# Altium schematic sheet 15_PMU.SchDoc
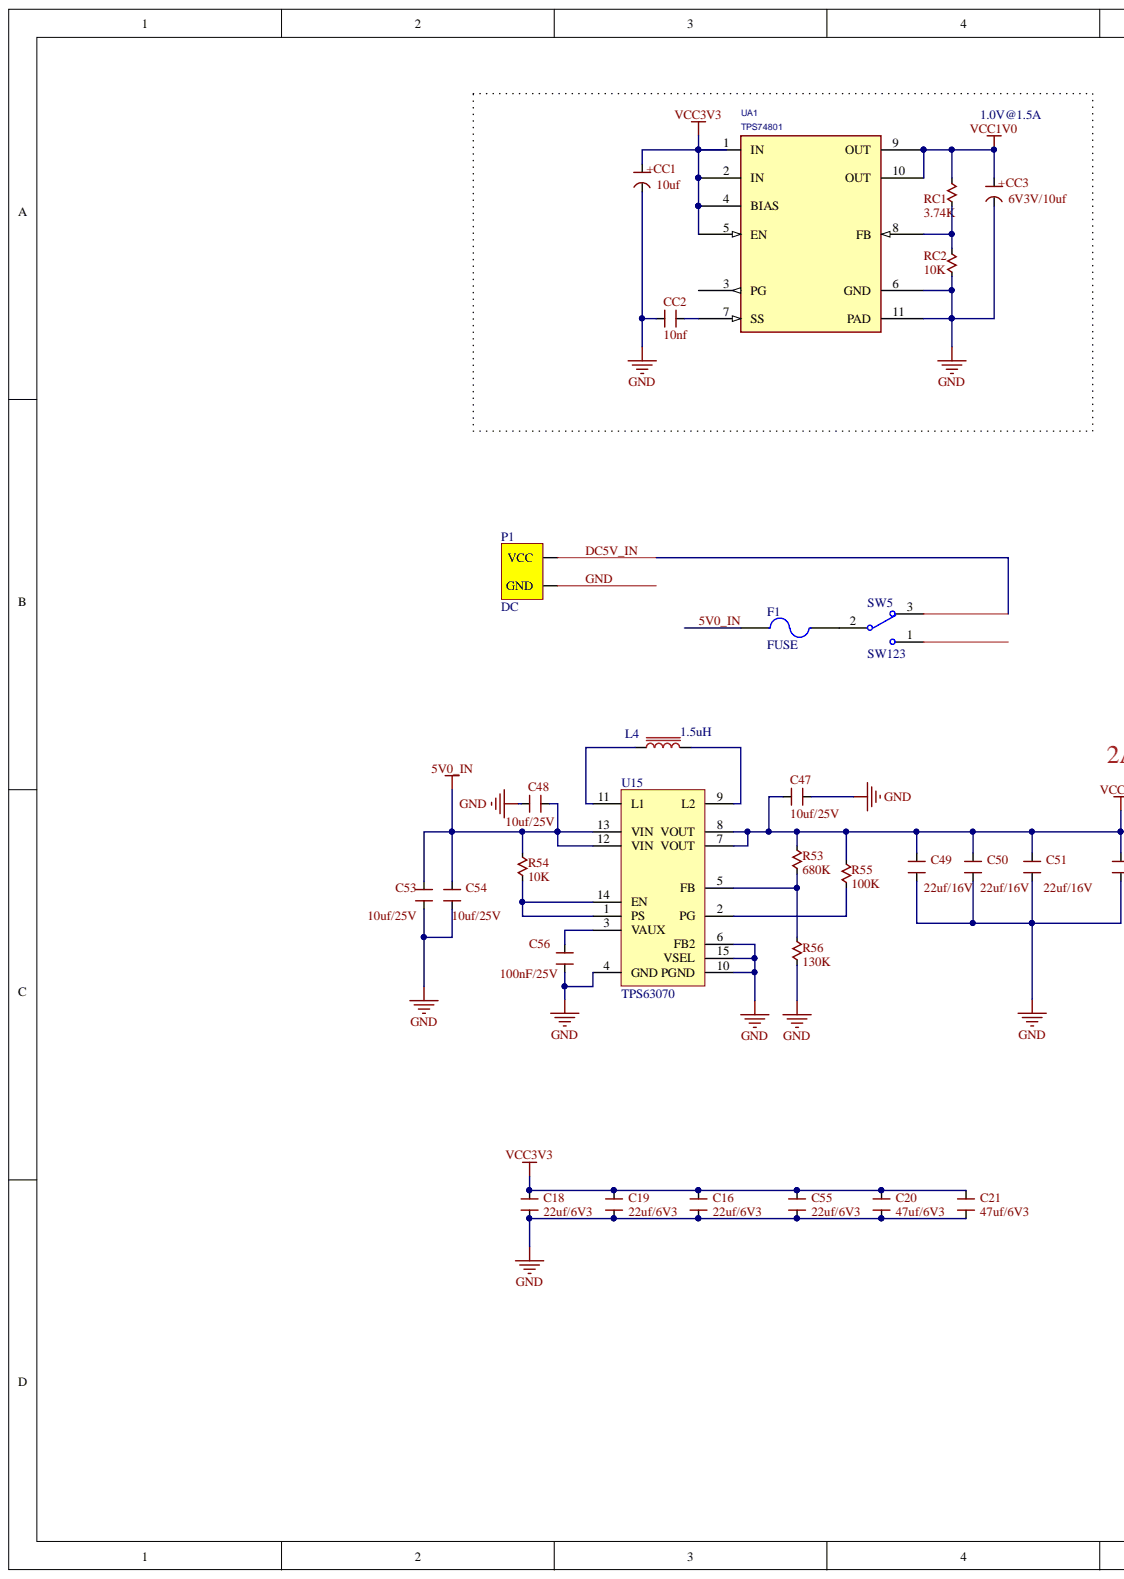
[diagram p0: 15_PMU.SchDoc, left]
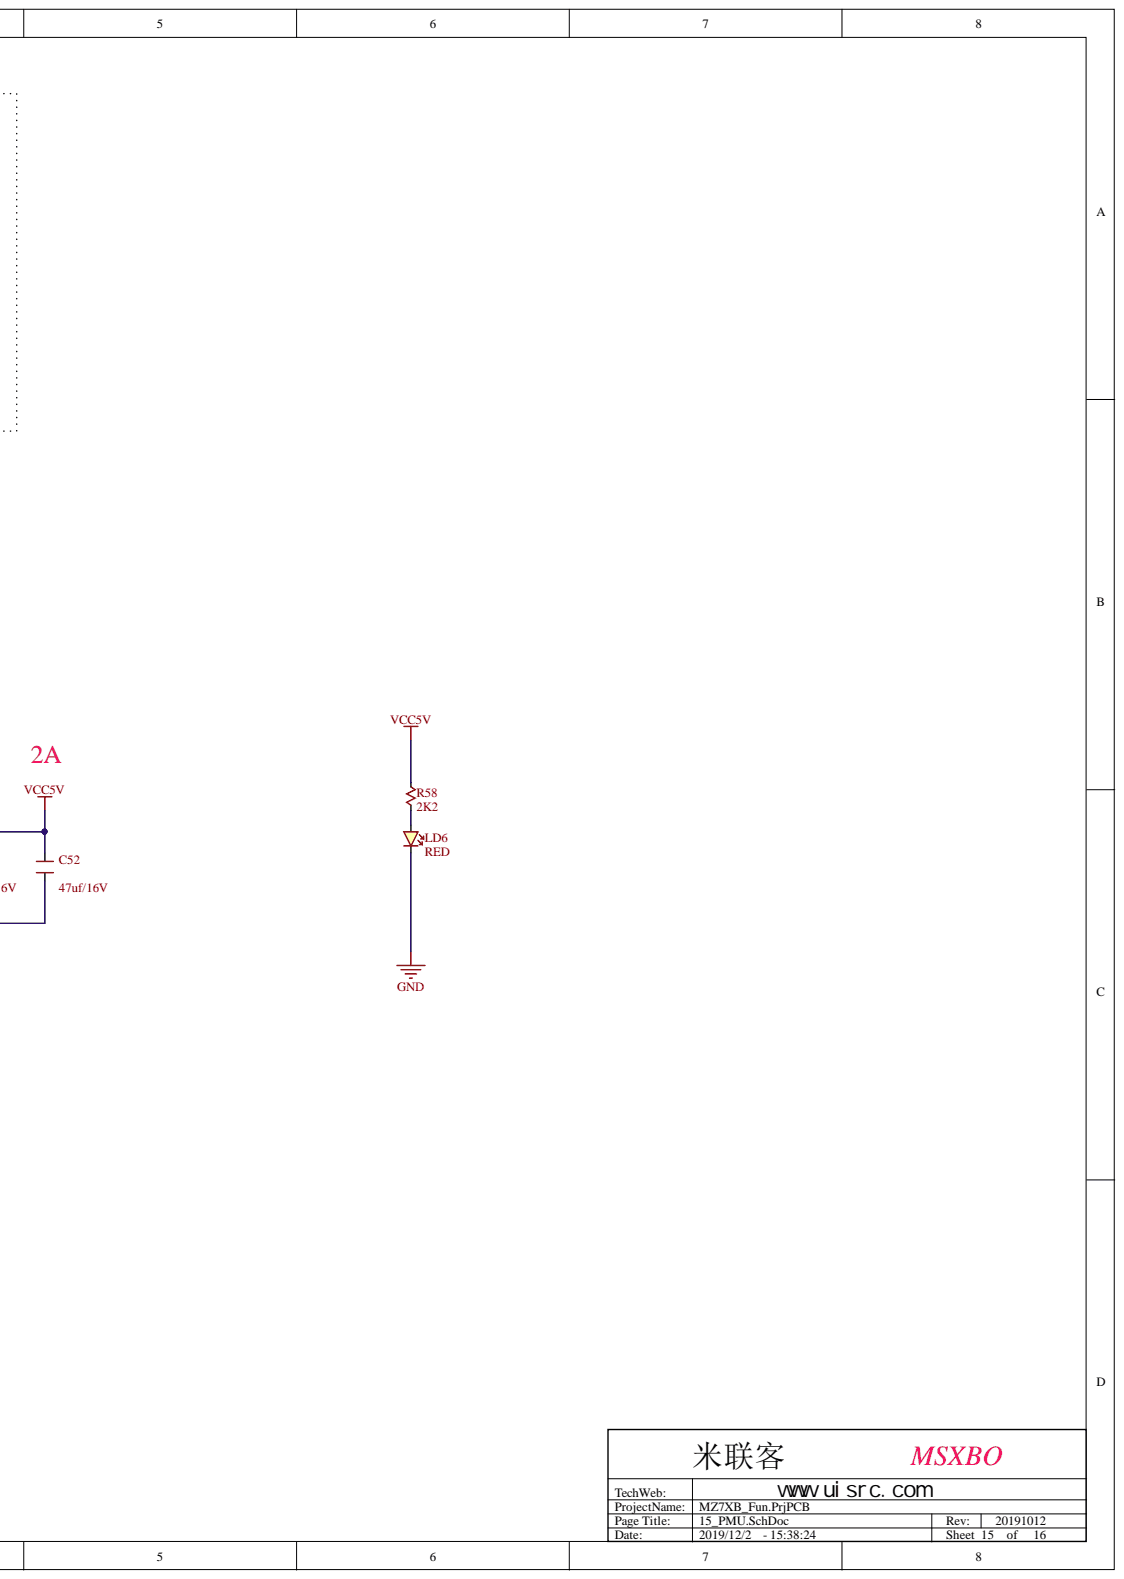
[diagram p1: 15_PMU.SchDoc, right]

## Parts
C16  22uf/6V3  [CAP0805]
C18  22uf/6V3  [CAP0805]
C19  22uf/6V3  [CAP0805]
C20  47uf/6V3  [CAP0805]
C21  47uf/6V3  [CAP0805]
C47  10uf/25V  [CAP0603]
C48  10uf/25V  [CAP0603]
C49  22uf/16V  [CAP0805]
C50  22uf/16V  [CAP0805]
C51  22uf/16V  [CAP0805]
C52  47uf/16V  [CAP0805]
C53  10uf/25V  [CAP0805]
C54  10uf/25V  [CAP0805]
C55  22uf/6V3  [CAP0805]
C56  100nF/25V  [CAP0402]
CC1  10uf  [CAP0603]
CC2  10nf  [CAP0402]
CC3  6V3V/10uf  [CAP0603]
F1  FUSE  [1206]
L4  1.5uH  [XFL0402]
LD6  value RED  [D0603]  (hidden comment: LED)
P1  DC  [DC5.0]
R53  680K  [CAP0603]
R54  10K  [CAP0603]
R55  100K  [CAP0603]
R56  130K  [CAP0603]
R58  2K2  [RES0402]
RC1  3.74K  [RES0402]
RC2  10K  [RES0402]
SW5  SW123  [SW1-2-3 -B]
U15  TPS63070  [LQ_VQFN15]
UA1  TPS74801  [VQFN10]

## Nets
VCC1V0: CC3.1 RC1.2 UA1.9(OUT) UA1.10(OUT)
VCC3V3: C16.1 C18.1 C19.1 C20.1 C21.1 C55.1 CC1.1 UA1.1(IN) UA1.2(IN) UA1.4(BIAS) UA1.5(EN)
VCC5V: C47.1 C49.1 C50.1 C51.1 C52.1 R53.1 R55.1 R58.1 U15.7(VOUT) U15.8(VOUT)
5V0_IN: C48.1 C53.1 C54.1 F1.1 R54.1 U15.12(VIN) U15.13(VIN)
DC5V_IN: P1.1 SW5.3
GND: C16.2 C18.2 C19.2 C20.2 C21.2 C47.2 C48.2 C49.2 C50.2 C51.2 C52.2 C53.2 C54.2 C55.2 C56.2 CC1.2 CC2.2 CC3.2 LD6.K P1.2 R56.2 RC2.1 U15.4(GND) U15.6(FB2) U15.10(PGND) U15.15(VSEL) UA1.6(GND) UA1.11(PAD)
NetC56_1: C56.1 U15.3(VAUX)
NetCC2_1: CC2.1 UA1.7(SS)
NetF1_2: F1.2 SW5.2
NetL4_1: L4.1 U15.11(L1)
NetL4_2: L4.2 U15.9(L2)
NetLD6_A: LD6.A R58.2
NetR53_2: R53.2 R56.1 U15.5(FB)
NetR54_2: R54.2 U15.1(PS) U15.14(EN)
NetR55_2: R55.2 U15.2(PG)
NetRC1_1: RC1.1 RC2.2 UA1.8(FB)

Not connected: SW5 1; UA1 3(PG)

## Library data of the parts
C16 C18-C21 C49-C55 = CAPACITOR: Generic Capacitor; Value: 4.7uF; Component Kind: Standard; Library Name: Digilent.IntLib
C47 C48 CC2 = CAPACITOR: Generic Capacitor; Component Kind: Standard; Library Name: Digilent.IntLib
C56 = CAPACITOR: Generic Capacitor; Value: 10nF; Component Kind: Standard; Library Name: Digilent.IntLib
CC1 CC3 = CPOL: Generic Polarized Capacitor; Component Kind: Standard; Library Name: Digilent.IntLib
F1 = Fuse 2: Component Kind: Standard; Description: Fuse; LatestRevisionDate: 17-Jul-2002; LatestRevisionNote: Re-released for DXP Platform.; PackageReference: PIN-W2/E2.8; Published: 8-Jun-2000; Simulation: FUSE; Library Name: jinrylib.IntLib; Publisher: Altium Limited
LD6 = LED: Generic LED; Component Kind: Standard; Library Name: Digilent.IntLib
R53-R56 = RESISTOR: Generic Resistor; Value: 4.7K; Component Kind: Standard; Library Name: Digilent.IntLib
R58 = RESISTOR: Generic Resistor; Value: 390; Component Kind: Standard; Library Name: Digilent.IntLib
RC1 RC2 = RESISTOR: Generic Resistor; Component Kind: Standard; Library Name: Digilent.IntLib
L4 = 1.5uH: Component Kind: Standard
P1 = DC: Component Kind: Standard; Description: DC; Library Name: jinrylib.IntLib
SW5 = SW123: Component Kind: Standard; Library Name: jinrylib.IntLib
U15 = TPS63070: Component Kind: Standard
UA1 = TPS74801: Component Kind: Standard
